# Altium schematic sheet Magnetometer.SchDoc
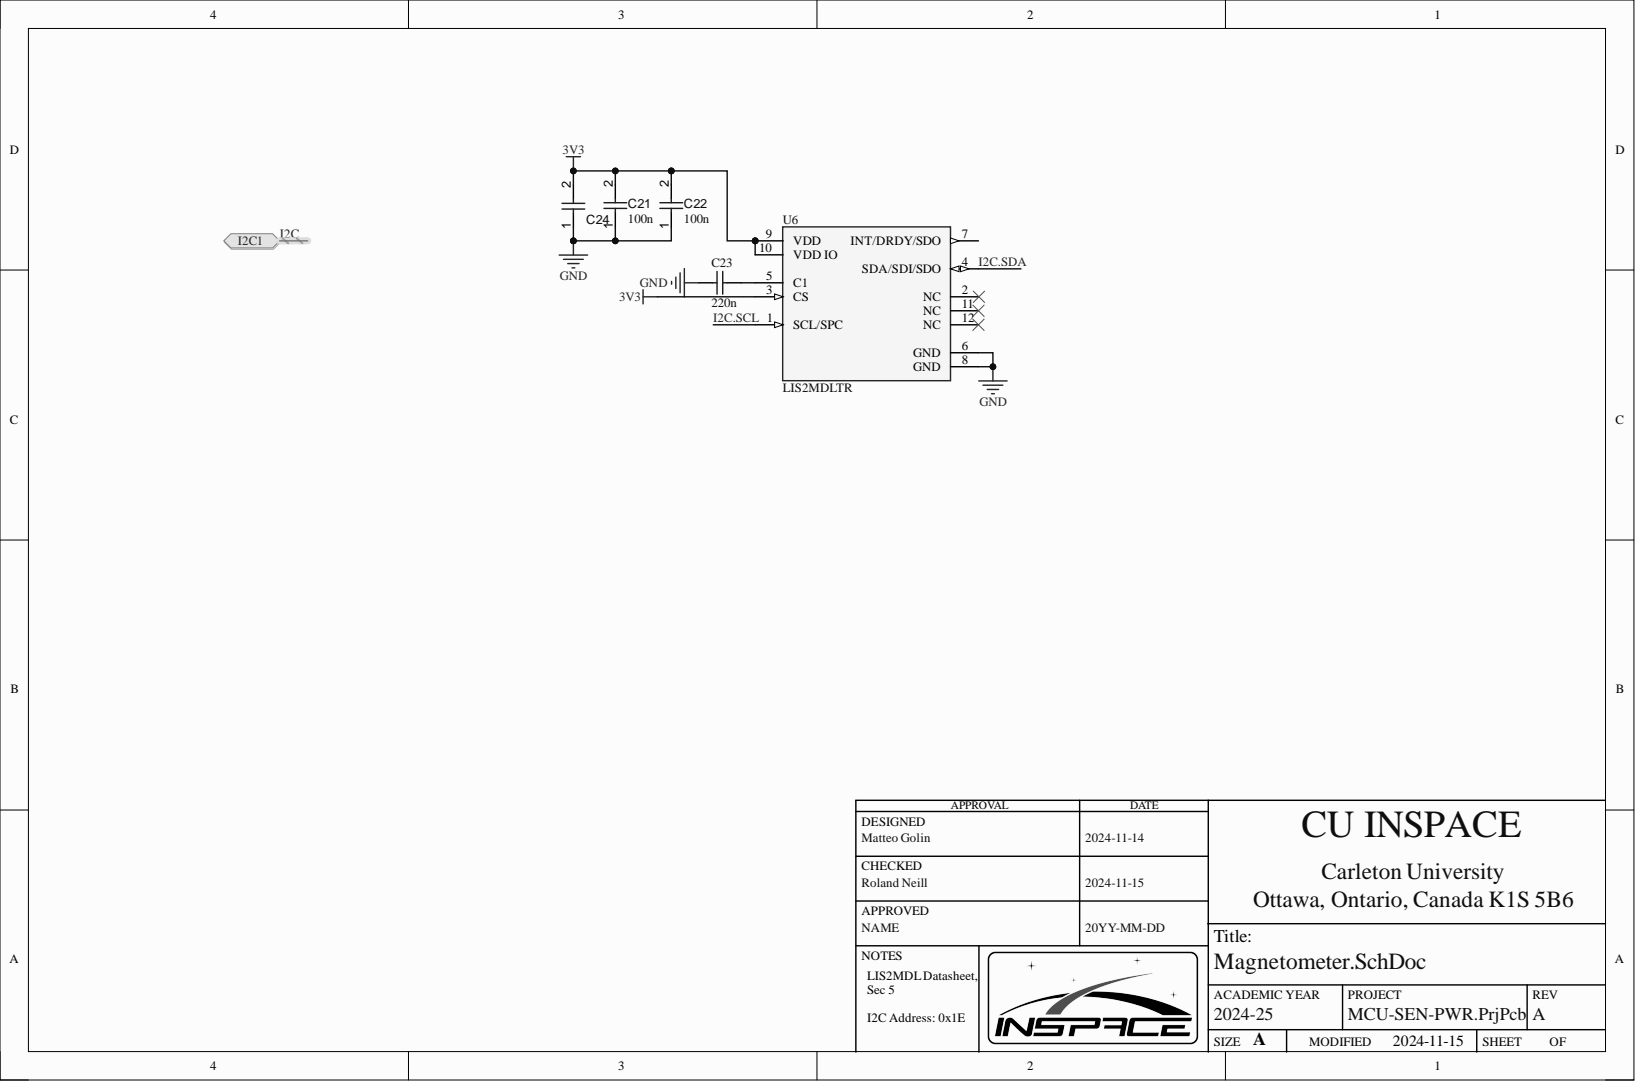
[diagram p0: Magnetometer.SchDoc]

## Parts
C21  value 100n  [CAPC1005X56X25LL10T15]  (hidden comment: Capacitor 0.1 uF +/- 5% 16 V 0402)
C22  value 100n  [CAPC1005X56X25LL10T15]  (hidden comment: Capacitor 0.1 uF +/- 5% 16 V 0402)
C23  value 220n  (hidden comment: Capacitor)
C24  Capacitors,10uF  (value not drawn)
U6  LIS2MDLTR  [FP-LGA-12-DM00170568-MFG]

## Ports
I2C1, I2C1.SCL, I2C1.SDA

## Nets
3V3: C21.2 C22.2 C24.2 U6.3(CS) U6.9(VDD) U6.10(VDD_IO)
GND: C21.1 C22.1 C23.1 C24.1 U6.6(GND) U6.8(GND)
I2C.SCL: U6.1(SCL/SPC) ; port I2C1
I2C.SDA: U6.4(SDA/SDI/SDO) ; port I2C1
NetC23_2: C23.2 U6.5(C1)

Not connected: U6 2(NC) 7(INT/DRDY/SDO) 11(NC) 12(NC)

## Library data of the parts
C21 C22 = CMP-001-00001-6: Chip Capacitor, 0.1 uF, +/- 5%, 16 V, 0402 (1005 Metric); Capacitor Type: Ceramic; Voltage Rating: 16V; Case/Package: 0402; Component Kind: Standard; Max Operating Temperature: 125°C; Min Operating Temperature: -55°C; Mounting Technology: SM; Pins: 2; RoHS Compliant: True; Tolerance: 5%; Library Name: Americas- CU InSpace-  Carleton University
C23 = Capacitor: Capacitor  Max ESR 200mOhms; SimulationGenericComponent: True; Component Kind: Standard; Library Name: Simulation Generic Components.IntLib; Simulation: C_Capacitor_Default
C24 = Capacitors: Capacitor; Component Kind: Standard; Component Type: Capacitors; Value: 10uF; Library Name: Americas- CU InSpace-  Carleton University
U6 = CMP-010-00033-1: Hall Effect Sensor, Square, Surface Mount; Body Breadth: 2mm; Body Height: 0.7mm; Max Supply Voltage: 3.6V; Min Supply Voltage: 1.71V; Output Interface Type: 3-WIRE; Output Type: DIGITAL VOLTAGE; Sensor Type: MAGNETIC FIELD SENSOR,HALL EFFECT; Body Length or Diameter: 2mm; Component Kind: Standard; Housing Material: Plastic; JESD-609 Code: e4; Max Operating Temperature: 85°C; Min Operating Temperature: -40°C; Mounting Technology: Surface Mount; Package Shape/Style: Square; Reach SVHC Compliant: Yes; RoHS Compliant: Yes; Terminal Finish: Nickel; Termination: Solder; Library Name: Americas- CU InSpace-  Carleton University
